# Altium schematic sheet POWER.SchDoc
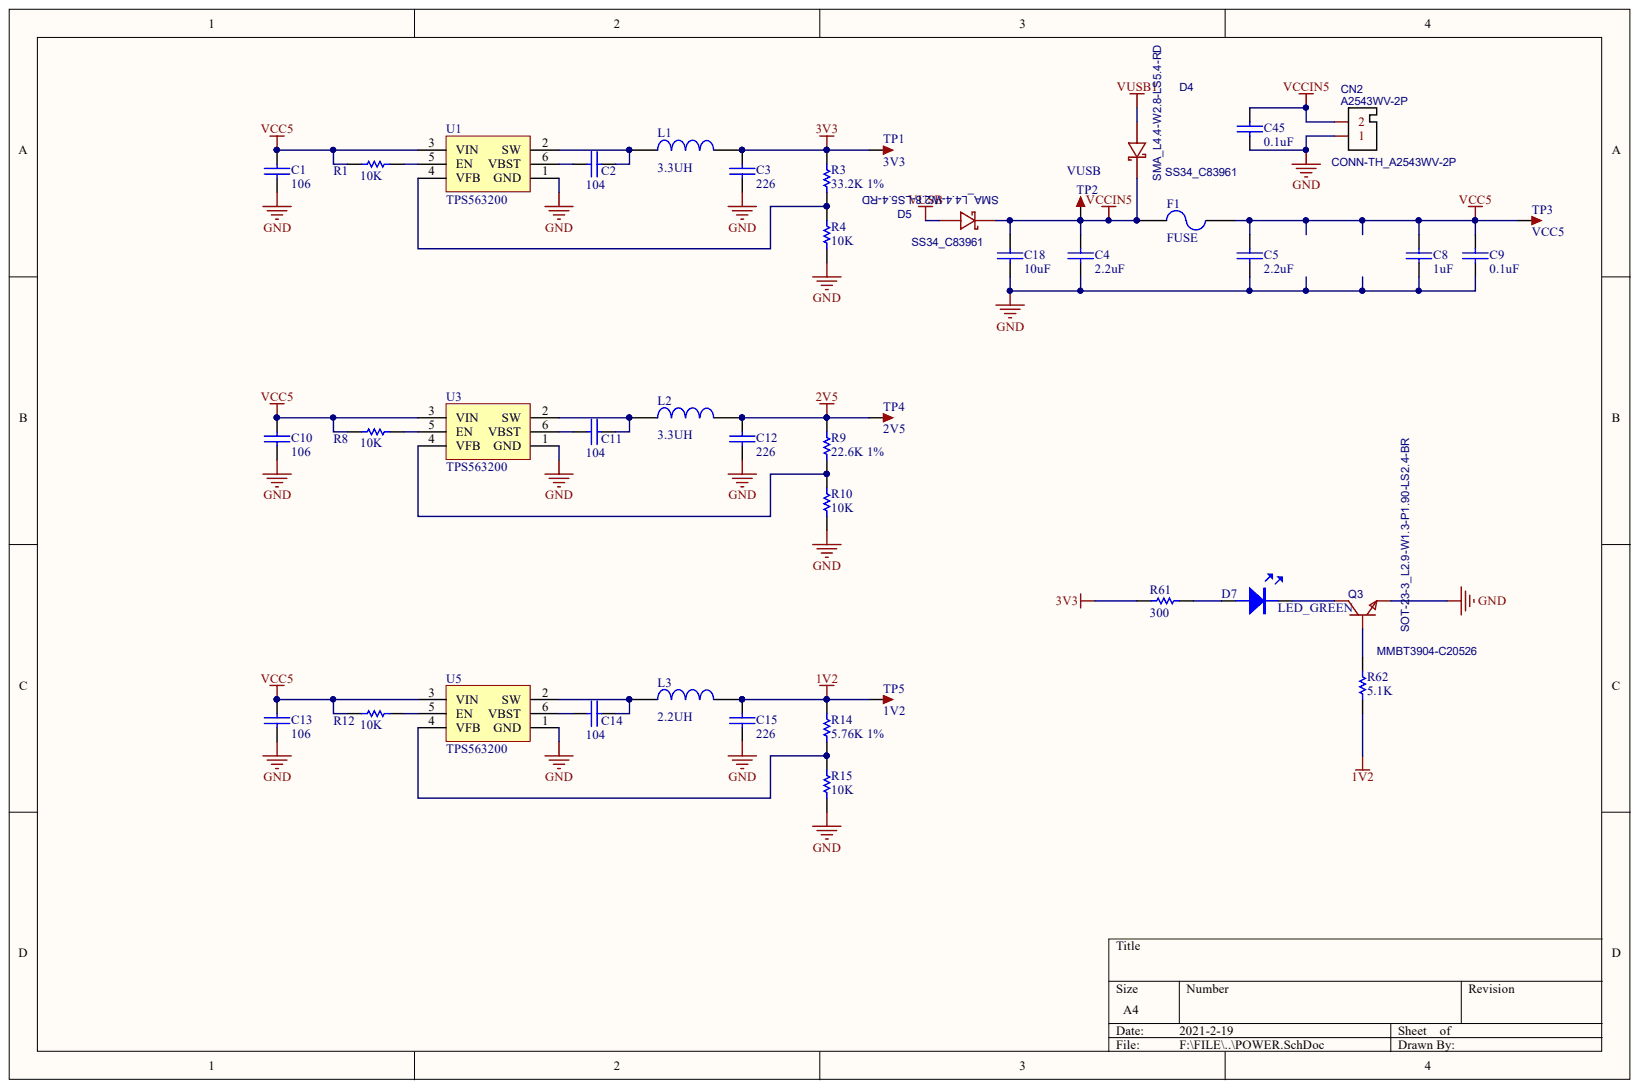
[diagram p0: POWER.SchDoc]

## Parts
C1  106  [0603C]
C2  104  [0603C]
C3  226  [0603C]
C4  2.2uF  [0603C]
C5  2.2uF  [C0402]
C8  1uF  [0603C]
C9  0.1uF  [0603C]
C10  106  [0603C]
C11  104  [0603C]
C12  226  [0603C]
C13  106  [0603C]
C14  104  [0603C]
C15  226  [0603C]
C18  10uF  [C0805]
C45  0.1uF  [0603C]
CN2  A2543WV-2P  [CONN-TH_A2543WV-2P]
D4  SS34_C83961  [SMA_L4.4-W2.8-LS5.4-RD]
D5  SS34_C83961  [SMA_L4.4-W2.8-LS5.4-RD]
D7  LED_GREEN  [LED-0603G]
F1  FUSE  [1206_]
L1  3.3UH  [0420L]
L2  3.3UH  [0420L]
L3  2.2UH  [0420L]
Q3  MMBT3904-C20526  [SOT-23-3_L2.9-W1.3-P1.90-LS2.4-BR]
R1  10K  [R0402]
R3  33.2K 1%  [R0402]
R4  10K  [R0402]
R8  10K  [R0402]
R9  22.6K 1%  [R0402]
R10  10K  [R0402]
R12  10K  [R0402]
R14  5.76K 1%  [R0402]
R15  10K  [R0402]
R61  300  [R0402]
R62  5.1K  [R0402]
TP1  3V3  [TEST 0.8]
TP2  VUSB  [TEST 0.8]
TP3  VCC5  [TEST 0.8]
TP4  2V5  [TEST 0.8]
TP5  1V2  [TEST 0.8]
U1  TPS563200  [SOT23-6]
U3  TPS563200  [SOT23-6]
U5  TPS563200  [SOT23-6]

## Nets
1V2: C15.1 L3.2 R14.1 R62.1 TP5.1
2V5: C12.1 L2.2 R9.1 TP4.1
3V3: C3.1 L1.2 R3.1 R61.1 TP1.1
GND: C1.1 C3.2 C4.2 C5.2 C8.2 C9.2 C10.1 C12.2 C13.1 C15.2 C18.2 C45.2 CN2.1 Q3.2 R4.2 R10.2 R15.2 U1.1(GND) U3.1(GND) U5.1(GND)
VCC5: C1.2 C5.1 C8.1 C9.1 C10.2 C13.2 F1.2 R1.2 R8.2 R12.2 TP3.1 U1.3(VIN) U3.3(VIN) U5.3(VIN)
VCCIN5: C4.1 C18.1 C45.1 CN2.2 D4.1 D5.1 F1.1 TP2.1
VUSB: D5.2
VUSB1: D4.2
NetC2_1: C2.1 L1.1 U1.2(SW)
NetC2_2: C2.2 U1.6(VBST)
NetC11_1: C11.1 L2.1 U3.2(SW)
NetC11_2: C11.2 U3.6(VBST)
NetC14_1: C14.1 L3.1 U5.2(SW)
NetC14_2: C14.2 U5.6(VBST)
NetD7_A: D7.A R61.2
NetD7_K: D7.K Q3.3
NetQ3_1: Q3.1 R62.2
NetR1_1: R1.1 U1.5(EN)
NetR3_2: R3.2 R4.1 U1.4(VFB)
NetR8_1: R8.1 U3.5(EN)
NetR9_2: R9.2 R10.1 U3.4(VFB)
NetR12_1: R12.1 U5.5(EN)
NetR14_2: R14.2 R15.1 U5.4(VFB)

## Library data of the parts
C1-C5 C8-C15 C18 C45 = CAP: 无极性电容; Component Kind: Standard; Library Name: 电容.IntLib
CN2 = A2543WV-2P: BOM_Manufacturer: Changjiang Connectors; Contributor: LCSC; Package Display: Yes; BOM_Manufacturer Part: A2543WV-2P; BOM_Supplier: LCSC; BOM_Supplier Part: C239362; Component Kind: Standard; link: https://item.szlcsc.com/238466.html?ref=editor&logined=true; spicePre: C; spiceSymbolName: A2543WV-2P
D4 D5 = SS34_C83961: BOM_Manufacturer: STARSEA; BOM_Manufacturer Part: SS34; Package Display: Yes; SMT Type: Extend; BOM_JLCPCB SMD: Yes; BOM_Supplier: LCSC; BOM_Supplier Part: C83961; Component Kind: Standard; image: //image.lceda.cn/szlcsc/C83961.jpg; spicePre: D; spiceSymbolName: SS34_C83961
D7 = LED: Component Kind: Standard; Library Name: LED.IntLib
L1-L3 = INDUCTOR: Component Kind: Standard; Description: INDUCTOR; Library Name: 电感.IntLib
Q3 = MMBT3904-C20526: BOM_Manufacturer: CJ; Contributor: 立创EDA官方封装库; Package Display: Yes; SMT Type: Basic; BOM_JLCPCB SMD: Yes; BOM_Manufacturer Part: MMBT3904; BOM_Supplier: LCSC; BOM_Supplier Part: C20526; Component Kind: Standard; spicePre: Q; spiceSymbolName: MMBT3904-C20526
R1 R3 R4 R8-R10 R12 R14 R15 R61 R62 = RES: Component Kind: Standard
  R1 R3 R4 R8-R10 R12 R14 R15 R62: Library Name: 电阻.IntLib
  R61: Library Name: the_god_of_death.IntLib
TP1-TP5 = TEST: 测试点; 版权归属: 源创客; BOM名称: 测试点; Component Kind: Standard; 学习资源: www.ooocke.com; Library Name: 测试调试.IntLib
F1 = FUSE: Component Kind: Standard; Library Name: 保险丝.IntLib
U1 U3 U5 = TPS563200: Component Kind: Standard; Library Name: 未分类IC.IntLib
